# Altium schematic sheet Zynq-Power.SchDoc
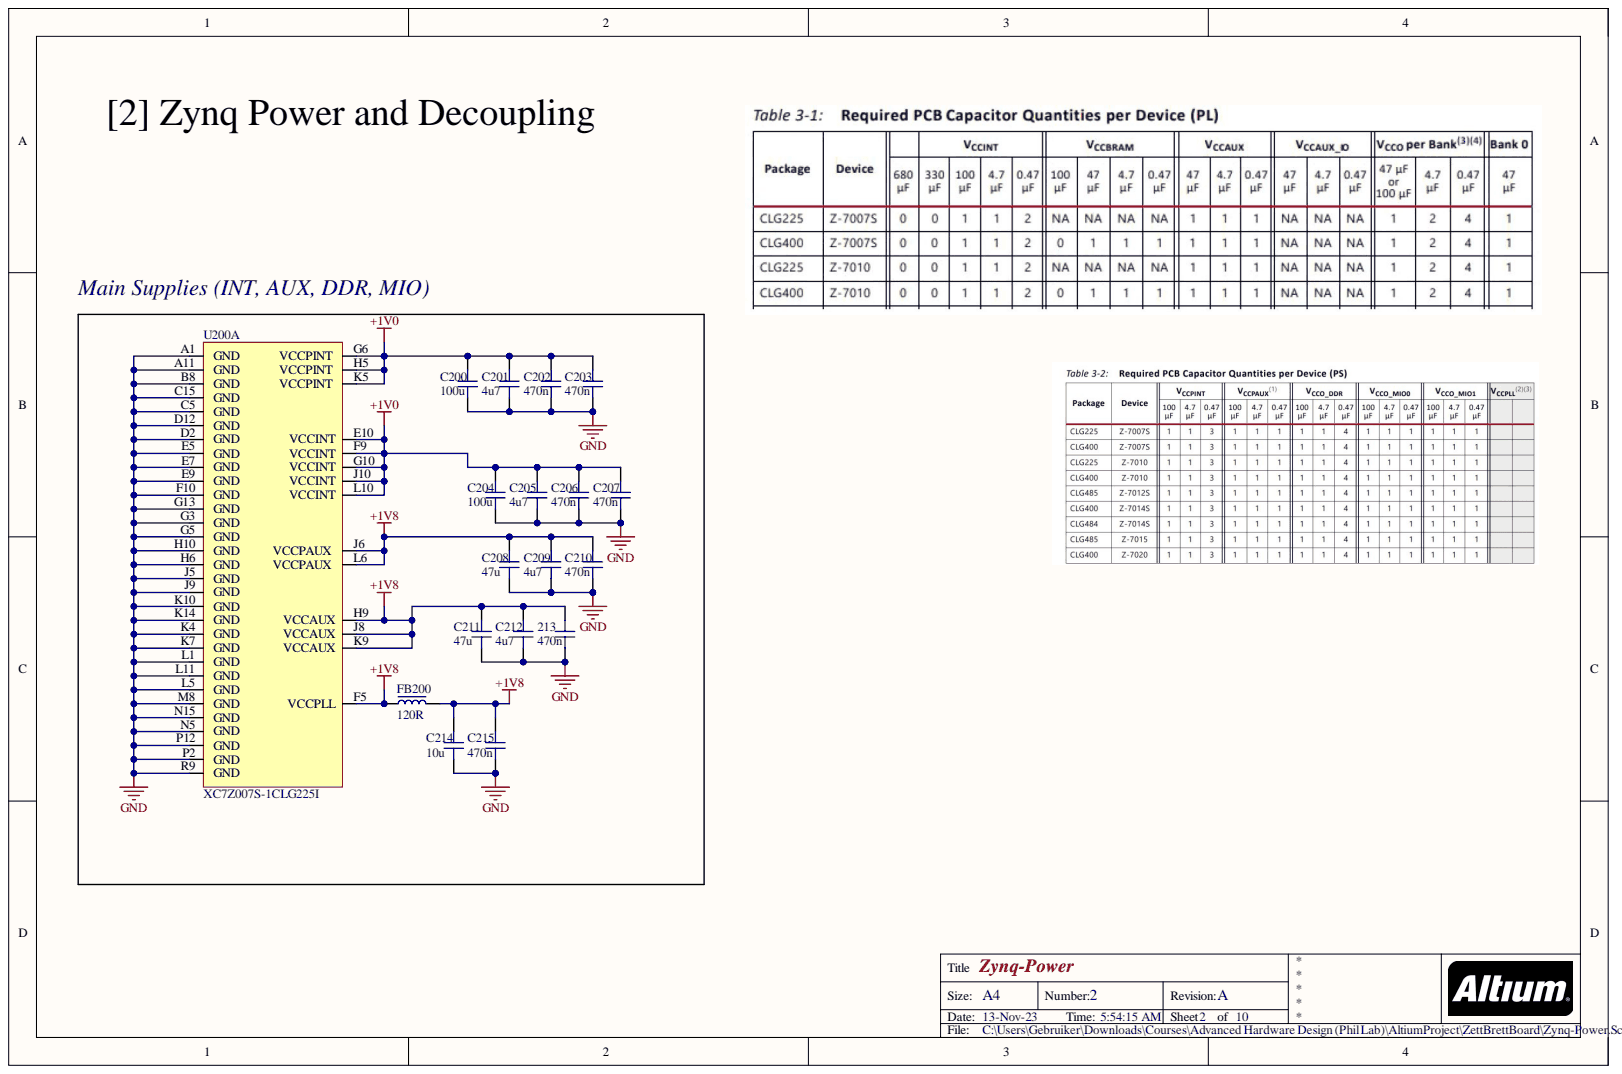
[diagram p0: Zynq-Power.SchDoc]

## Parts
213  470n  [CAP_1210]
C200  100u  [CAP_1210]
C201  4u7  [CAP_1210]
C202  470n  [CAP_1210]
C203  470n  [CAP_1210]
C204  100u  [CAP_1210]
C205  4u7  [CAP_1210]
C206  470n  [CAP_1210]
C207  470n  [CAP_1210]
C208  47u  [CAP_1210]
C209  4u7  [CAP_1210]
C210  470n  [CAP_1210]
C211  47u  [CAP_1210]
C212  4u7  [CAP_1210]
C214  10u  [CAP_1210]
C215  470n  [CAP_1210]
FB200  120R  [FB_0402]
U200  XC7Z007S-1CLG225I  [CLG225]  (part A)

## Nets
+1V0: C200.2 C201.2 C202.2 C203.2 C204.2 C205.2 C206.2 C207.2 U200.E10 U200.F9 U200.G6 U200.G10 U200.H5 U200.J10 U200.K5 U200.L10
+1V8: 213.2 C208.2 C209.2 C210.2 C211.2 C212.2 C214.2 C215.2 FB200.1 FB200.2 U200.F5 U200.H9 U200.J6 U200.J8 U200.K9 U200.L6
GND: 213.1 C200.1 C201.1 C202.1 C203.1 C204.1 C205.1 C206.1 C207.1 C208.1 C209.1 C210.1 C211.1 C212.1 C214.1 C215.1 U200.A1 U200.A11 U200.B8 U200.C5 U200.C15 U200.D2 U200.D12 U200.E5 U200.E7 U200.E9 U200.F10 U200.G3 U200.G5 U200.G13 U200.H6 U200.H10 U200.J5 U200.J9 U200.K4 U200.K7 U200.K10 U200.K14 U200.L1 U200.L5 U200.L11 U200.M8 U200.N5 U200.N15 U200.P2 U200.P12 U200.R9

## Library data of the parts
213 C200-C212 C214 C215 = CAP_1210: Capacitor SMD 1210; Component Kind: Standard; Library Name: Schematic-Lib-PhilsLab.SchLib
FB200 = FB_0402: Ferrite Bead SMD 0402; Component Kind: Standard; Library Name: Schematic-Lib-PhilsLab.SchLib
U200 = XC7Z007S-1CLG225I: Xilinx FPGA SoC Zynq 7-Series XC7Z007S CLG255 Package Industrial Temperature Grade; Component Kind: Standard; Manufacturer: Xilinx; Manufacturer Part Number: XC7Z007S-1CLG225I; Library Name: Schematic-Lib-PhilsLab.SchLib
